# Altium schematic sheet [04] - CAN.SchDoc("[04] - CAN")
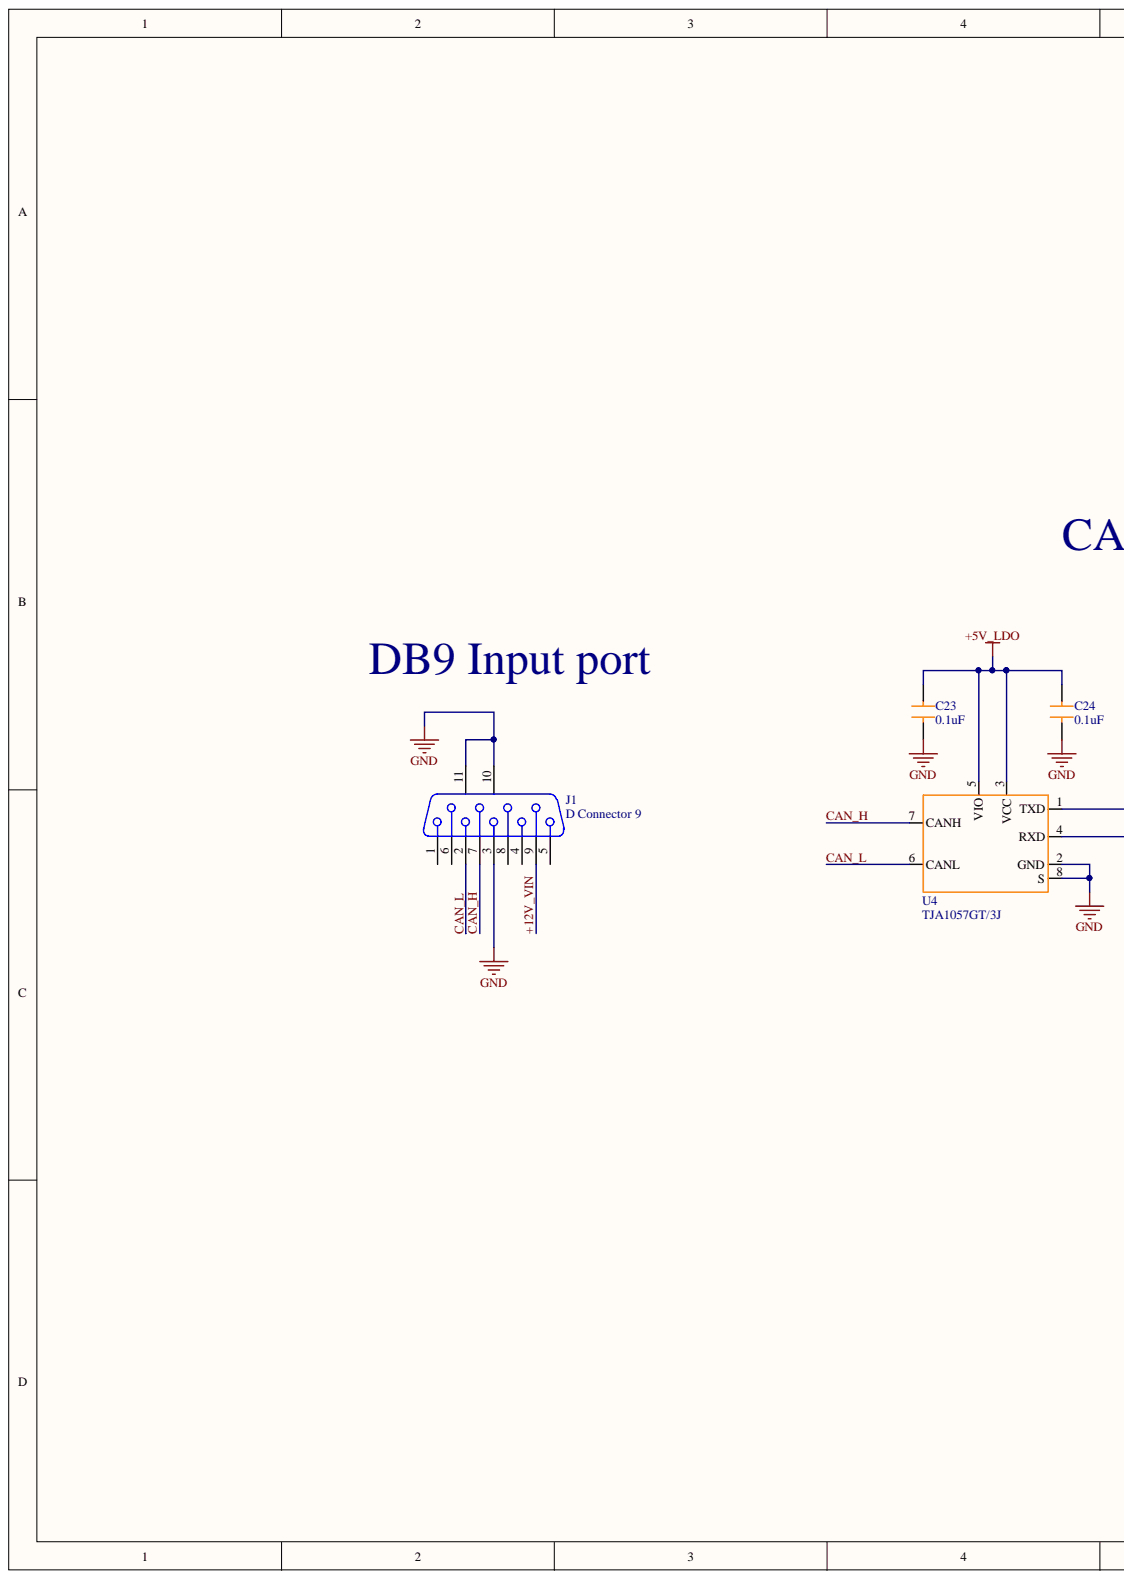
[diagram p0: [04] - CAN.SchDoc, left]
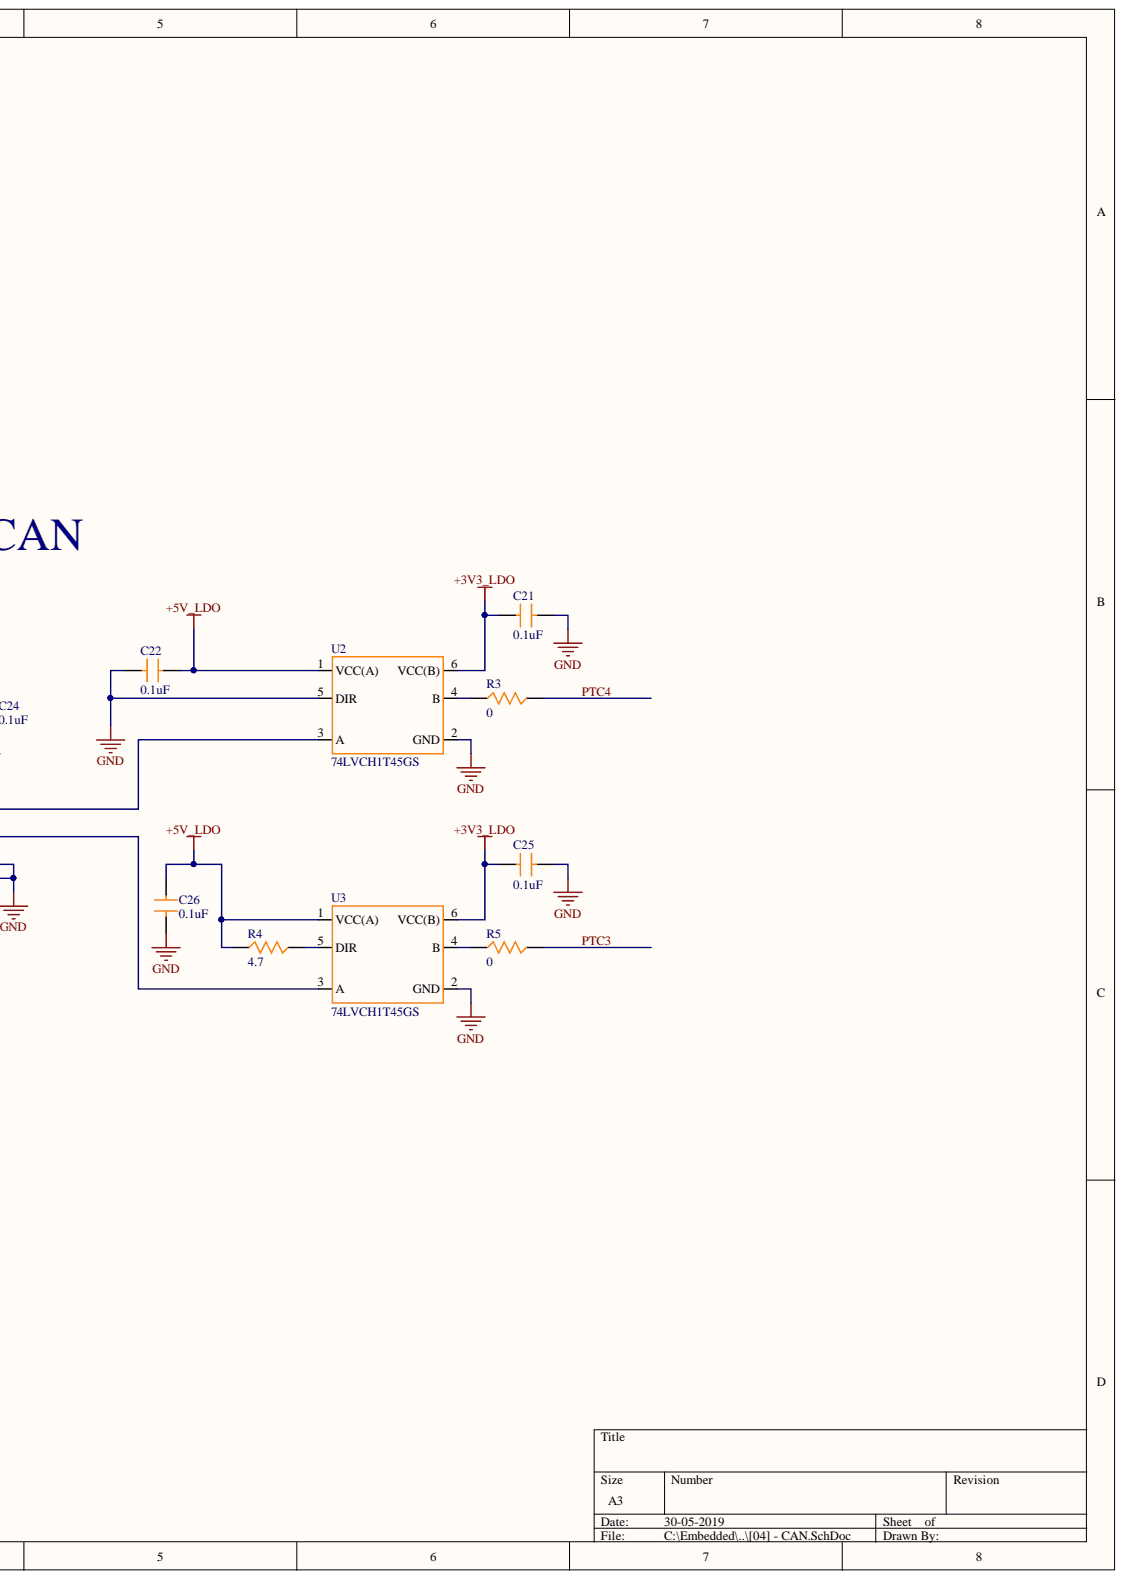
[diagram p1: [04] - CAN.SchDoc, right]

## Parts
C21  0.1uF  [C0805]
C22  0.1uF  [C0805]
C23  0.1uF  [C0805]
C24  0.1uF  [C0805]
C25  0.1uF  [C0805]
C26  0.1uF  [C0805]
J1  D Connector 9  [DSUB1.385-2H9]
R3  0  [R0805]
R4  4.7  [R0805]
R5  0  [R0805]
U2  74LVCH1T45GS  [74LVCH1T45GS,132]
U3  74LVCH1T45GS  [74LVCH1T45GS,132]
U4  TJA1057GT/3J  [TJA1057GT/3J]

## Nets
+3V3_LDO: C21.2 C25.2 U2.6(VCC(B)) U3.6(VCC(B))
+5V_LDO: C22.2 C23.2 C24.2 C26.2 R4.1 U2.1(VCC(A)) U3.1(VCC(A)) U4.3(VCC) U4.5(VIO)
GND: C21.1 C22.1 C23.1 C24.1 C25.1 C26.1 J1.3 J1.10 J1.11 U2.2(GND) U2.5(DIR) U3.2(GND) U4.2(GND) U4.8(S)
+12V_VIN: J1.9
CAN_H: J1.7 U4.7(CANH)
CAN_L: J1.2 U4.6(CANL)
PTC3: R5.2
PTC4: R3.2
NetR3_1: R3.1 U2.4(B)
NetR4_2: R4.2 U3.5(DIR)
NetR5_1: R5.1 U3.4(B)
NetU2_3: U2.3(A) U4.1(TXD)
NetU3_3: U3.3(A) U4.4(RXD)

Not connected: J1 1 4 5 6 8

## Library data of the parts
C21-C26 = C_0805-0.1uF: Multilayer Ceramic Capacitors MLCC - SMD/SMT 0.1uF 10% 50V AEC-Q200; Manufacturer Part Number: AS0805KKX7R9BB104; Brand: Yageo; Capacitance - nF: 100 nF; Capacitance - pF: 100000 pF; CNHTS: 8532241000; Dielectric: X7R; Product Status: New Product; TARIC: 8532240000; Voltage Rating DC: 50 VDC; Capacitance: 0.1 uF; Case Code - in: 0805; Case Code - mm: 2212; Category: Multilayer Ceramic Capacitors MLCC - SMD/SMT; Component Kind: Standard; ComponentLink1Description: http://www.mouser.com/datasheet/2/447/yageodocoutput-1313910.pdf; ComponentLink1URL: http://www.mouser.com/datasheet/2/447/yageodocoutput-1313910.pdf; Height: 1.25 mm; HTS Code: 8532240020; Length: 2 mm; Manufacturer: Yageo; Maximum Operating Temperature: + 125 C; Minimum Operating Temperature: - 55 C; Minimum Order Quantity: 1; Package / Case: 0805 (2012 metric); Packaging: Cut Tape; Pricing 1: 1=0.670, 10=0.461, 100=0.262, 500=0.238, 1000=0.165, 3000=0.156, 6000=0.146, 9000=0.136, 24000=0.122 (USD); Product: Automotive MLCCs; Product Type: Ceramic Capacitors; Reeling: True; RoHS: RoHS Compliant; RoHS - Mouser: Y; Series: AS; Standard Pack Qty: 3000; Stock 1: 30283; Subcategory: Capacitors; Supplier 1: Mouser; Supplier Part Number 1: 603-AS0805KKX79BB104; Termination: Flexible (Soft); Termination Style: SMD/SMT; Tolerance: 10 %; Width: 1.25 mm; Library Name: Joule_Library.IntLib
J1 = D Connector 9: Receptacle Assembly, 9 Position, Right Angle; PackageDocument: O; Positions: 9; Component Kind: Standard; DatasheetDocument: 1-Sep-1999; Gender: Receptacle; LatestRevisionDate: 17-Jul-2002; LatestRevisionNote: Re-released for DXP Platform.; Library Name: Miscellaneous Connectors.IntLib; Orientation: Right Angle; PackageReference: 788750; Published: 15-Jun-2001; Signal Integrity: Connector; Publisher: Altium Limited
R3 R5 = R_0805-0: Current Sense Resistors - SMD 0 ohm Jumper 1/2W; Manufacturer Part Number: PA0805-R-470RL; Brand: Yageo; CNHTS: 8533211000; Power Rating: 500 mW (1/2 W); Product Status: New Product; Resistance: 0 Ohms; TARIC: 8533210000; Application: Current Sense; Case Code - in: 0805; Case Code - mm: 2012; Category: Current Sense Resistors - SMD; Component Kind: Standard; ComponentLink1Description: http://www.mouser.com/datasheet/2/447/PYu-PA0805_L_51_RoHS_0-1480254.pdf; ComponentLink1URL: http://www.mouser.com/datasheet/2/447/PYu-PA0805_L_51_RoHS_0-1480254.pdf; HTS Code: 8533210030; Length: 2.03 mm; Manufacturer: Yageo; Maximum Operating Temperature: + 155 C; Minimum Operating Temperature: - 55 C; Minimum Order Quantity: 1; Mounting Style: PCB Mount; Packaging: Cut Tape; Pricing 1: 1=1.30, 10=1.06, 100=0.706, 500=0.595, 1000=0.495, 5000=0.431, 10000=0.421, 25000=0.409 (USD); Product: Current Sense Chip Resistor Surface Mount; Product Type: Current Sense Resistors; Reeling: True; RoHS: RoHS Compliant; RoHS - Mouser: Y; Series: PA_L; Standard Pack Qty: 5000; Stock 1: 3927; Subcategory: Resistors; Supplier 1: Mouser; Supplier Part Number 1: 603-PA0805-R-470RL; Technology: Metal Element; Termination: 2 Terminal; Termination Style: SMD/SMT; Tolerance: -; Type: Low TCR Current Sense Resistor; Width: 1.27 mm; Library Name: Joule_Library.IntLib
R4 = R_0805-4.7: Thin Film Resistors - SMD 4.7 ohm 1% 50 ppm High-Precision; Manufacturer Part Number: RT0805FRE074R7L; Brand: Yageo; Power Rating: 125 mW (1/8 W); Product Status: New Product; Resistance: 4.7 Ohms; Temperature Coefficient: 50 PPM / C; Voltage Rating: 150 V; Case Code - in: 0805; Case Code - mm: 2012; Category: Thin Film Resistors - SMD; Component Kind: Standard; ComponentLink1Description: https://www.mouser.com/datasheet/2/447/PYu-RT_1-to-0.01_RoHS_L_9-1222720.pdf; ComponentLink1URL: https://www.mouser.com/datasheet/2/447/PYu-RT_1-to-0.01_RoHS_L_9-1222720.pdf; Features: -; Height: 0.5 mm; Length: 2 mm; Manufacturer: Yageo; Maximum Operating Temperature: + 155 C; Minimum Operating Temperature: - 55 C; Minimum Order Quantity: 1; Package / Case: 0805 (2012 metric); Packaging: Cut Tape; Pricing 1: 1=0.180, 10=0.112, 100=0.037, 1000=0.026, 5000=0.019, 10000=0.018, 25000=0.017 (USD); Product: Precision Resistors Thin Film SMD; Reeling: True; RoHS: RoHS Compliant; RoHS - Mouser: Y; Series: RT; Standard Pack Qty: 5000; Stock 1: 0; Supplier 1: Mouser; Supplier Part Number 1: 603-RT0805FRE074R7L; Termination Style: SMD/SMT; Tolerance: 1 %; Width: 1.25 mm; Library Name: Joule_Library.IntLib
U2 U3 = 74LVCH1T45GS,132: Bus Transceivers 3.6V 250mW 8.2ns; Brand: Nexperia; Supply Voltage - Max: 5.5 V; Supply Voltage - Min: 1.2 V; Category: Bus Transceivers; Component Kind: Standard; ComponentLink1Description: https://www.mouser.com/datasheet/2/916/74LVC_LVCH1T45-1319147.pdf; ComponentLink1URL: https://www.mouser.com/datasheet/2/916/74LVC_LVCH1T45-1319147.pdf; Library Name: ICs.SchLib; Manufacturer: Nexperia; Manufacturer Part Number: 74LVCH1T45GS,132; Maximum Operating Temperature: + 125 C; Minimum Order Quantity: 1; Mounting Style: SMD/SMT; Package / Case: XSON-6; Packaging: Cut Tape; Pd - Power Dissipation: 250 mW; Pricing 1: 1=0.590, 10=0.487, 100=0.314, 1000=0.252, 5000=0.212, 10000=0.204, 25000=0.197, 50000=0.193 (USD); Product Type: Bus Transceivers; Propagation Delay Time: 8.2 ns; Reeling: True; RoHS: RoHS Compliant; RoHS - Mouser: Y; Standard Pack Qty: 5000; Stock 1: 7431; Subcategory: Logic ICs; Supplier 1: Mouser; Supplier Part Number 1: 771-74LVCH1T45GS132
U4 = TJA1057GT/3J: CAN Interface IC High-speed CAN transceiver; Brand: NXP Semiconductors; Data Rate: 5 Mb/s; Number of Drivers: 1 Driver; Number of Receivers: 1 Receiver; Operating Supply Current: 80 mA; Operating Supply Voltage: 4.75 V to 5.25 V; Propagation Delay Time: 210 ns; Supply Voltage - Max: 5.25 V; Supply Voltage - Min: 4.75 V; Category: CAN Interface IC; Component Kind: Standard; ComponentLink1Description: https://www.mouser.com/datasheet/2/302/TJA1057-1127934.pdf; ComponentLink1URL: https://www.mouser.com/datasheet/2/302/TJA1057-1127934.pdf; Library Name: ICs.SchLib; Manufacturer: NXP Semiconductors; Manufacturer Part Number: TJA1057GT/3J; Maximum Operating Temperature: + 150 C; Minimum Operating Temperature: - 40 C; Minimum Order Quantity: 1; Mounting Style: SMD/SMT; Package / Case: SO-8; Packaging: Cut Tape; Pricing 1: 1=0.910, 10=0.771, 100=0.592, 500=0.523, 1000=0.413, 2500=0.367, 10000=0.353, 25000=0.342 (USD); Product: CAN Transceivers; Product Type: CAN Interface IC; Reeling: True; RoHS: RoHS Compliant; RoHS - Mouser: Y; Series: TJA1057; Standard Pack Qty: 2500; Stock 1: 18764; Subcategory: Interface ICs; Supplier 1: Mouser; Supplier Part Number 1: 771-TJA1057GT/3J; Type: High Speed
